# Altium schematic sheet testcore.SchDoc(testcore)
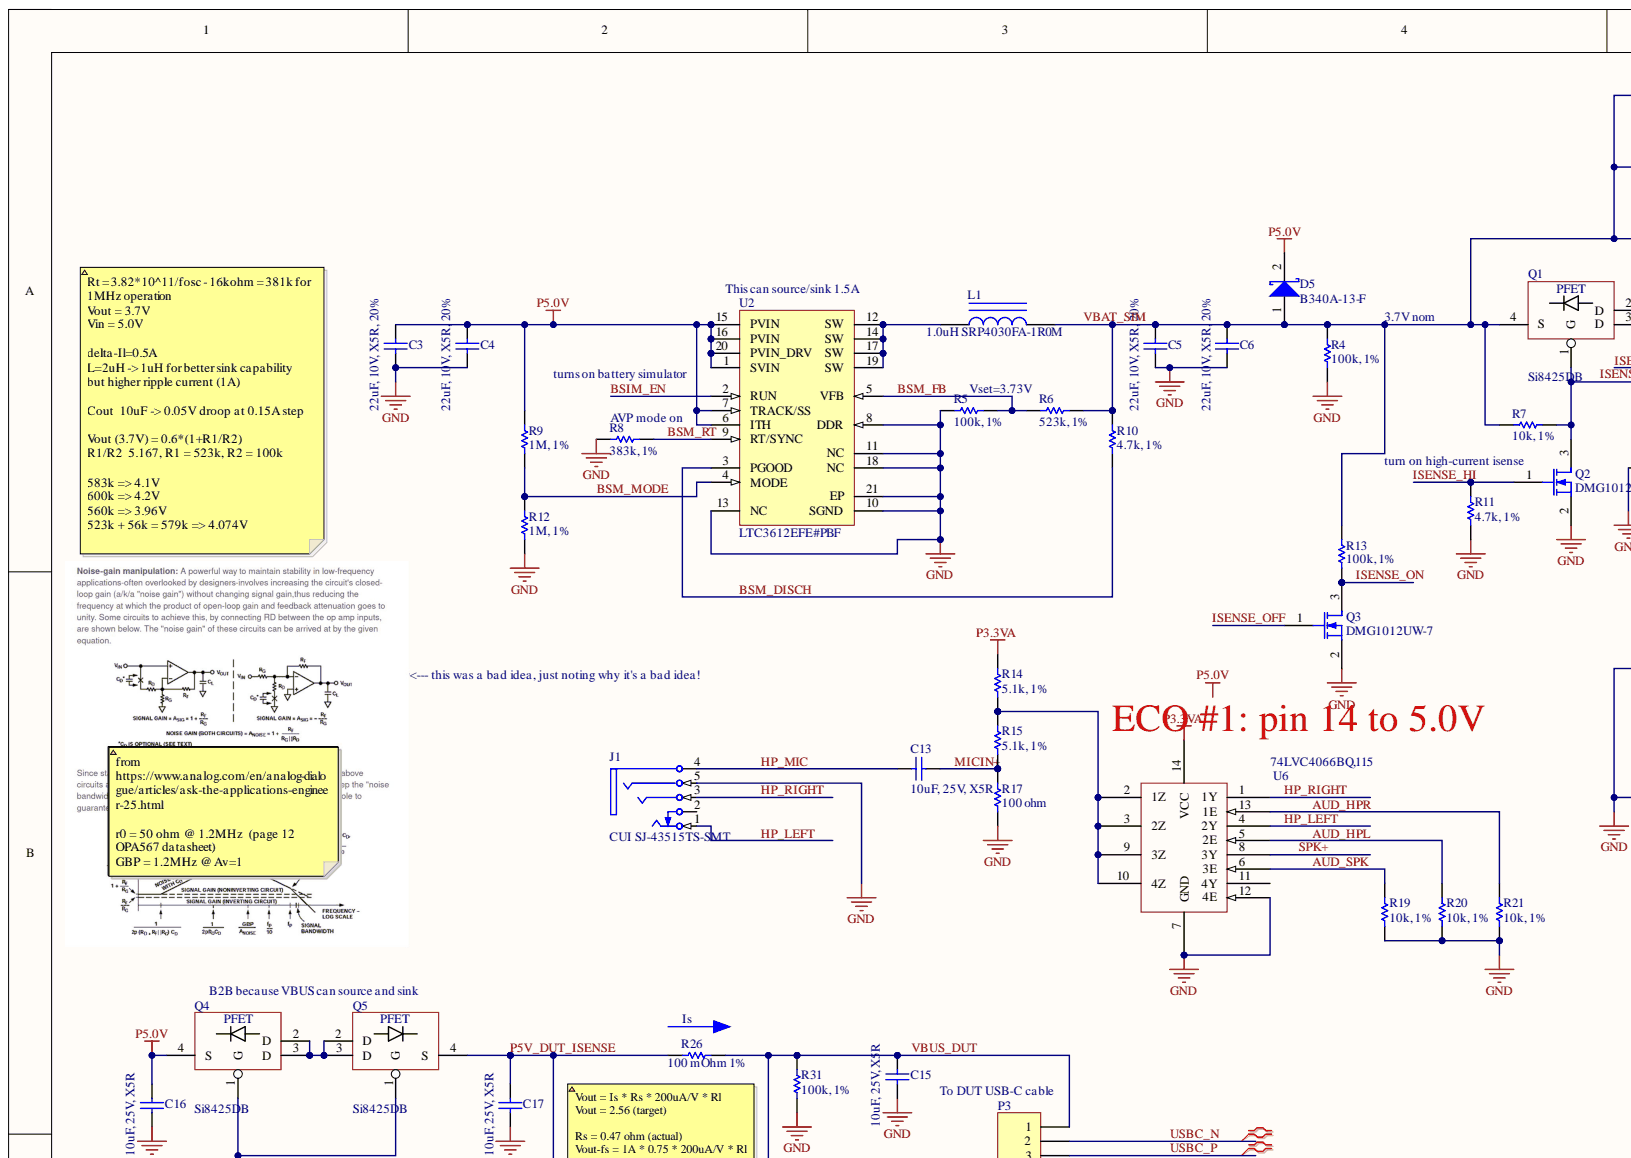
[diagram p0: testcore.SchDoc, top left]
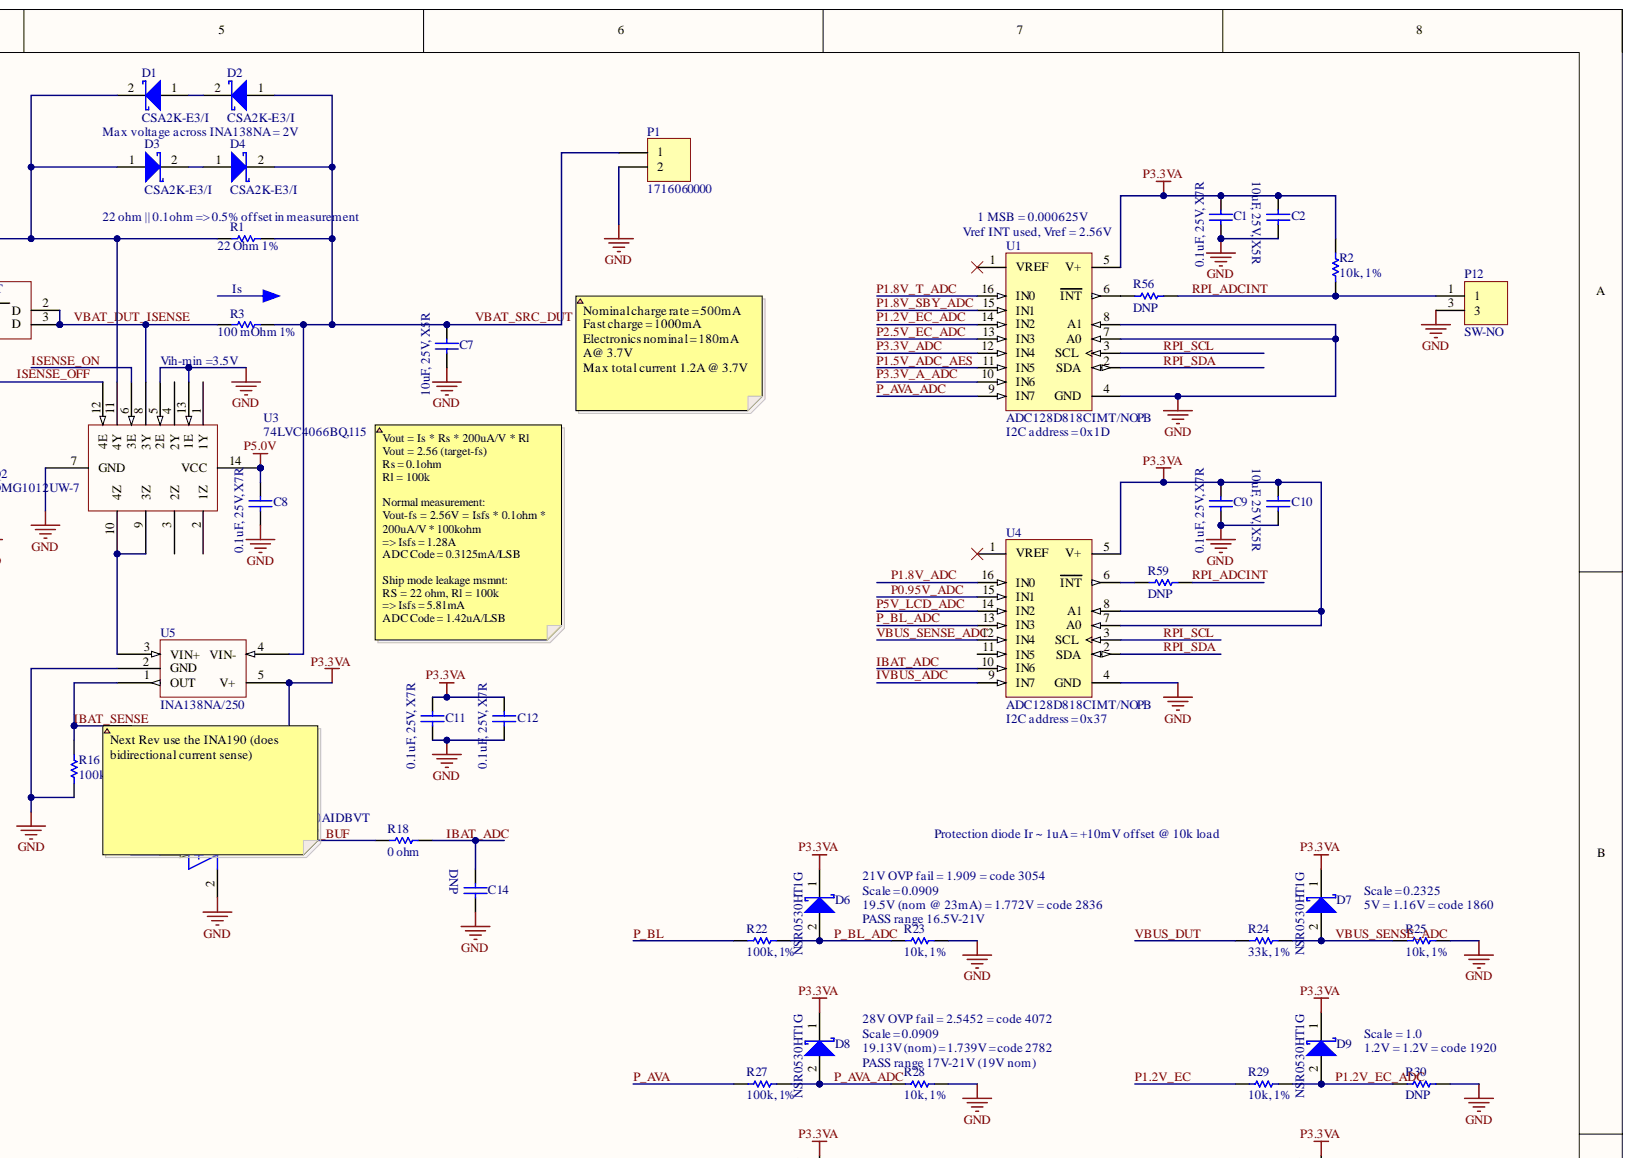
[diagram p1: testcore.SchDoc, top right]
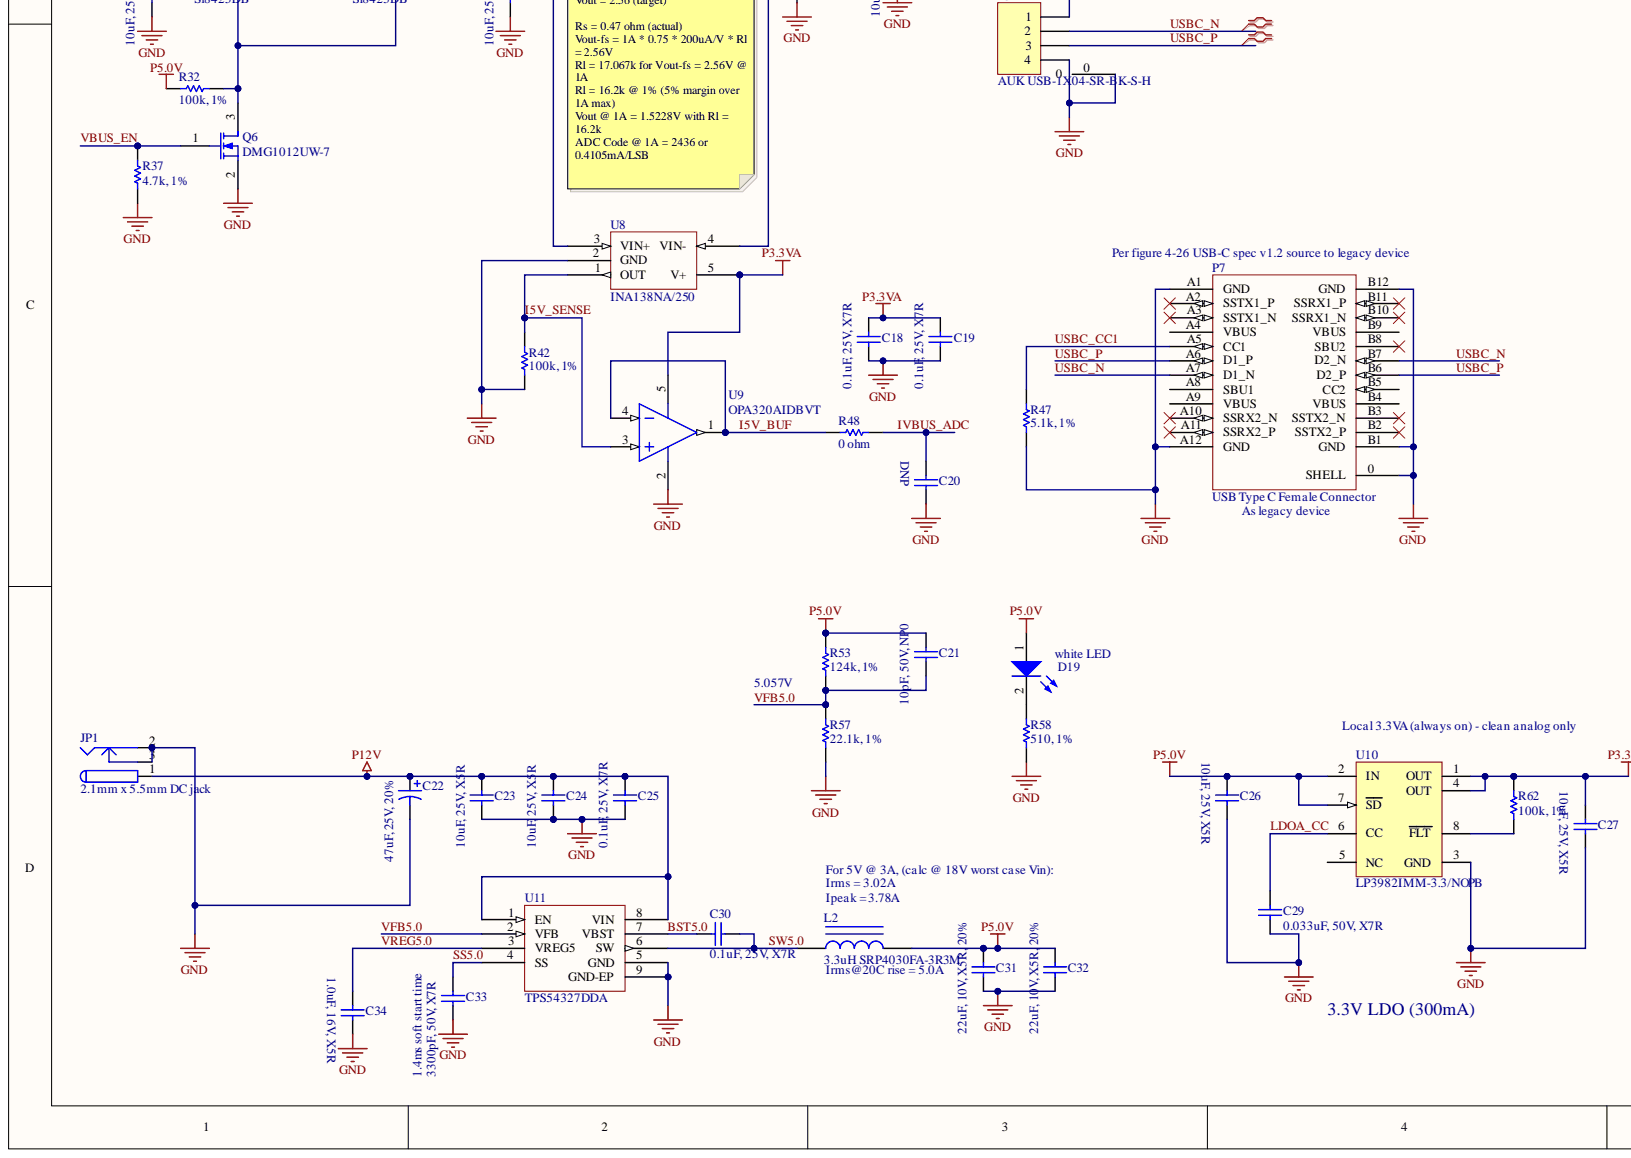
[diagram p2: testcore.SchDoc, bottom left]
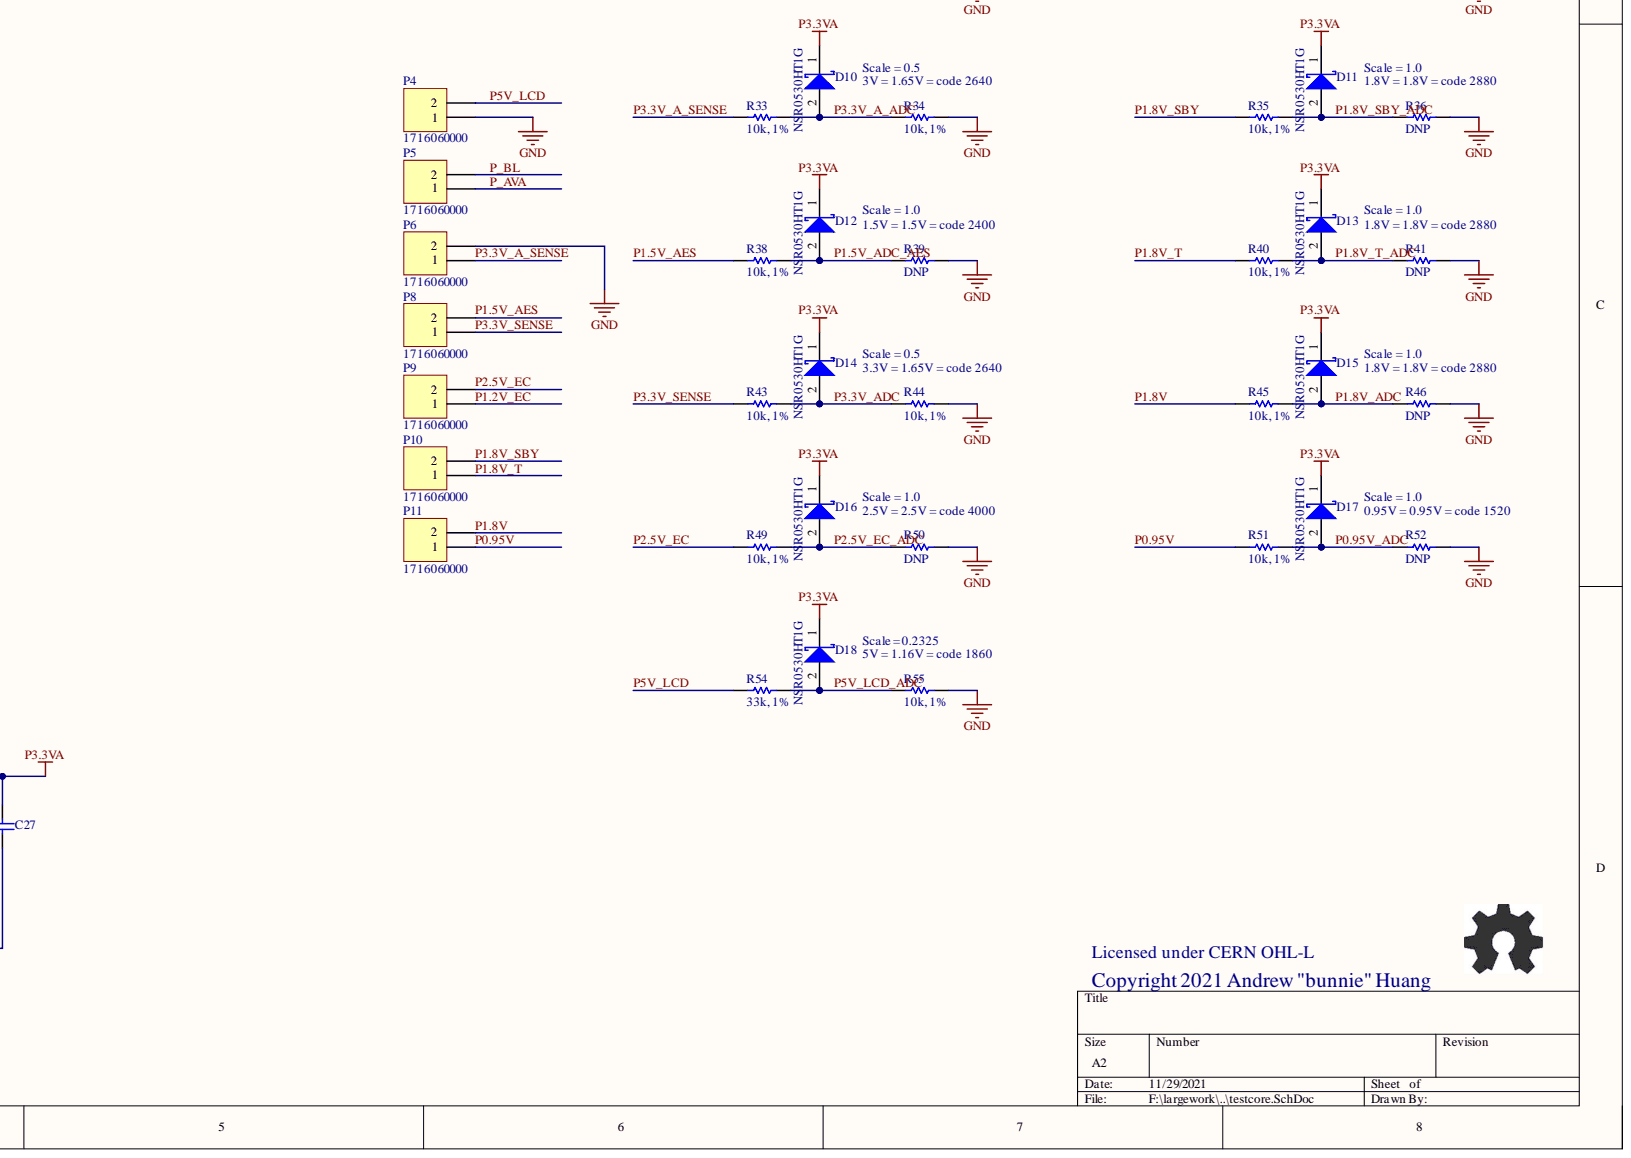
[diagram p3: testcore.SchDoc, bottom right]

## Parts
C1  0.1uF, 25V, X7R  [B_CAP0603]
C2  10uF, 25V, X5R  [B_CAP0805]
C3  22uF, 10V, X5R, 20%  [B_CAP0805]
C4  22uF, 10V, X5R, 20%  [B_CAP0805]
C5  22uF, 10V, X5R, 20%  [B_CAP0805]
C6  22uF, 10V, X5R, 20%  [B_CAP0805]
C7  10uF, 25V, X5R  [B_CAP0805]
C8  0.1uF, 25V, X7R  [B_CAP0603]
C9  0.1uF, 25V, X7R  [B_CAP0603]
C10  10uF, 25V, X5R  [B_CAP0805]
C11  0.1uF, 25V, X7R  [B_CAP0603]
C12  0.1uF, 25V, X7R  [B_CAP0603]
C13  10uF, 25V, X5R  [B_CAP0805]
C14  DNP  [B_CAP0603]
C15  10uF, 25V, X5R  [B_CAP0805]
C16  10uF, 25V, X5R  [B_CAP0805]
C17  10uF, 25V, X5R  [B_CAP0805]
C18  0.1uF, 25V, X7R  [B_CAP0603]
C19  0.1uF, 25V, X7R  [B_CAP0603]
C20  DNP  [B_CAP0603]
C21  10pF, 50V, NP0  [B_CAP0603]
C22  47uF, 25V, 20%  [CAPAE7066X61N]
C23  10uF, 25V, X5R  [B_CAP0805]
C24  10uF, 25V, X5R  [B_CAP0805]
C25  0.1uF, 25V, X7R  [B_CAP0603]
C26  10uF, 25V, X5R  [B_CAP0805]
C27  10uF, 25V, X5R  [B_CAP0805]
C29  0.033uF, 50V, X7R  [B_CAP0603]
C30  0.1uF, 25V, X7R  [B_CAP0603]
C31  22uF, 10V, X5R, 20%  [B_CAP0805]
C32  22uF, 10V, X5R, 20%  [B_CAP0805]
C33  3300pF, 50V, X7R  [B_CAP0603]
C34  1.0uF, 16V, X5R  [B_CAP0603]
D1  CSA2K-E3/I  [DSO-C2/X1.8]
D2  CSA2K-E3/I  [DSO-C2/X1.8]
D3  CSA2K-E3/I  [DSO-C2/X1.8]
D4  CSA2K-E3/I  [DSO-C2/X1.8]
D5  B340A-13-F  [DSO-C2/X1.8]
D6  NSR0530HT1G  [SOD-323]
D7  NSR0530HT1G  [SOD-323]
D8  NSR0530HT1G  [SOD-323]
D9  NSR0530HT1G  [SOD-323]
D10  NSR0530HT1G  [SOD-323]
D11  NSR0530HT1G  [SOD-323]
D12  NSR0530HT1G  [SOD-323]
D13  NSR0530HT1G  [SOD-323]
D14  NSR0530HT1G  [SOD-323]
D15  NSR0530HT1G  [SOD-323]
D16  NSR0530HT1G  [SOD-323]
D17  NSR0530HT1G  [SOD-323]
D18  NSR0530HT1G  [SOD-323]
D19  white LED  [B_LED0805]
J1  CUI SJ-43515TS-SMT  MPN: SJ-43515TS-SMT  VENDOR: CUI  [CUI_SJ_43515TS-SMT]
JP1  2.1mm x 5.5mm DC jack  [CUI_PJ_002AH_SMT]
L1  1.0uH SRP4030FA-1R0M  MPN: SRP4030FA-1R0M  [COILCRAFT_XEL4030]
L2  3.3uH SRP4030FA-3R3M  [COILCRAFT_XEL4030]
P1  1716060000  [weidmuller_1716060000]
P3  AUK USB-1X04-SR-BK-S-H  [USB_TYPEA_TH_SINGLE]
P4  1716060000  [weidmuller_1716060000]
P5  1716060000  [weidmuller_1716060000]
P6  1716060000  [weidmuller_1716060000]
P7  USB Type C Female Connector  [HIROSE_CX90B1-24P]
P8  1716060000  [weidmuller_1716060000]
P9  1716060000  [weidmuller_1716060000]
P10  1716060000  [weidmuller_1716060000]
P11  1716060000  [weidmuller_1716060000]
P12  SW-NO  [CK_KS11R22CQD]
Q1  Si8425DB  [VISHAY_MICRO_FOOT_4_BUMP]
Q2  DMG1012UW-7  [SOT323-3AN]
Q3  DMG1012UW-7  [SOT323-3AN]
Q4  Si8425DB  [VISHAY_MICRO_FOOT_4_BUMP]
Q5  Si8425DB  [VISHAY_MICRO_FOOT_4_BUMP]
Q6  DMG1012UW-7  [SOT323-3AN]
R1  22 Ohm 1%  [B_RES1206]
R2  10k, 1%  [B_RES0603]
R3  100 mOhm 1%  [B_RES1206]
R4  100k, 1%  [B_RES0603]
R5  100k, 1%  [B_RES0603]
R6  523k, 1%  [B_RES0603]
R7  10k, 1%  [B_RES0603]
R8  383k, 1%  [B_RES0603]
R9  1M, 1%  [B_RES0603]
R10  4.7k, 1%  [B_RES0603]
R11  4.7k, 1%  [B_RES0603]
R12  1M, 1%  [B_RES0603]
R13  100k, 1%  [B_RES0603]
R14  5.1k, 1%  [B_RES0603]
R15  5.1k, 1%  [B_RES0603]
R16  100k, 1%  [B_RES0603]
R17  100 ohm  [B_RES0603]
R18  0 ohm  [B_RES0603]
R19  10k, 1%  [B_RES0603]
R20  10k, 1%  [B_RES0603]
R21  10k, 1%  [B_RES0603]
R22  100k, 1%  [B_RES0603]
R23  10k, 1%  [B_RES0603]
R24  33k, 1%  [B_RES0603]
R25  10k, 1%  [B_RES0603]
R26  100 mOhm 1%  [B_RES1206]
R27  100k, 1%  [B_RES0603]
R28  10k, 1%  [B_RES0603]
R29  10k, 1%  [B_RES0603]
R30  DNP  [B_RES0603]
R31  100k, 1%  [B_RES0603]
R32  100k, 1%  [B_RES0603]
R33  10k, 1%  [B_RES0603]
R34  10k, 1%  [B_RES0603]
R35  10k, 1%  [B_RES0603]
R36  DNP  [B_RES0603]
R37  4.7k, 1%  [B_RES0603]
R38  10k, 1%  [B_RES0603]
R39  DNP  [B_RES0603]
R40  10k, 1%  [B_RES0603]
R41  DNP  [B_RES0603]
R42  100k, 1%  [B_RES0603]
R43  10k, 1%  [B_RES0603]
R44  10k, 1%  [B_RES0603]
R45  10k, 1%  [B_RES0603]
R46  DNP  [B_RES0603]
R47  5.1k, 1%  [B_RES0603]
R48  0 ohm  [B_RES0603]
R49  10k, 1%  [B_RES0603]
R50  DNP  [B_RES0603]
R51  10k, 1%  [B_RES0603]
R52  DNP  [B_RES0603]
R53  124k, 1%  [B_RES0603]
R54  33k, 1%  [B_RES0603]
R55  10k, 1%  [B_RES0603]
R56  DNP  [B_RES0603]
R57  22.1k, 1%  [B_RES0603]
R58  510, 1%  [B_RES0603]
R59  DNP  [B_RES0603]
R62  100k, 1%  [B_RES0603]
U1  ADC128D818CIMT/NOPB  [MTC16_N]
U2  LTC3612EFE#PBF  [LT-FE-20-EPV-CB_L]
U3  74LVC4066BQ,115  [NXP_DHVQFN14]
U4  ADC128D818CIMT/NOPB  [MTC16_N]
U5  INA138NA/250  [SOT23-5AN]
U6  74LVC4066BQ,115  [NXP_DHVQFN14]
U7  OPA320AIDBVT  [TI-DBV5_N]
U8  INA138NA/250  [SOT23-5AN]
U9  OPA320AIDBVT  [TI-DBV5_N]
U10  LP3982IMM-3.3/NOPB  [MUA08A_N]
U11  TPS54327DDA  [SOIC127P600-8DN]

## Nets
GND: C1.2 C2.2 C3.2 C4.2 C5.2 C6.2 C7.1 C8.2 C9.2 C10.2 C11.2 C12.2 C14.2 C15.1 C16.1 C17.1 C18.2 C19.2 C20.2 C22.2 C23.1 C24.1 C25.2 C26.2 C27.2 C29.1 C31.2 C32.2 C33.2 C34.2 J1.5 JP1.2 JP1.3 P1.2 P3.0 P3.4 P4.1 P6.2 P7.0(SHELL) P7.A1(GND) P7.A12(GND) P7.B1(GND) P7.B12(GND) P12.3 Q2.2 Q3.2 Q6.2 R4.2 R5.1 R8.2 R11.1 R12.1 R16.2 R17.1 R19.1 R20.1 R21.1 R23.2 R25.2 R28.2 R30.2 R31.2 R34.2 R36.2 R37.1 R39.2 R41.2 R42.2 R44.2 R46.2 R47.1 R50.2 R52.2 R55.2 R57.1 R58.2 U1.4(GND) U1.7(A0) U1.8(A1) U2.8(DDR) U2.10(SGND) U2.11(NC) U2.13(NC) U2.18(NC) U2.21(EP) U3.5(2E) U3.7(GND) U3.13(1E) U4.4(GND) U5.2(GND) U6.7(GND) U6.12(4E) U7.2 U8.2(GND) U9.2 U10.3(GND) U11.5(GND) U11.9(GND-EP)
P3.3VA: C1.1 C2.1 C9.1 C10.1 C11.1 C12.1 C18.1 C19.1 C27.1 D6.1 D7.1 D8.1 D9.1 D10.1 D11.1 D12.1 D13.1 D14.1 D15.1 D16.1 D17.1 D18.1 R2.2 R14.2 R62.2 U1.5(V+) U4.5(V+) U4.7(A0) U4.8(A1) U5.5(V+) U6.14(VCC) U7.5 U8.5(V+) U9.5 U10.1(OUT) U10.4(OUT)
P12V: C22.1 C23.2 C24.2 C25.1 JP1.1 U11.1(EN) U11.8(VIN)
AUD_HPL: R20.2 U6.5(2E)
AUD_HPR: R21.2 U6.13(1E)
AUD_SPK: R19.2 U6.6(3E)
BSIM_EN: U2.2(RUN)
BSM_DISCH: R10.1 U2.3(PGOOD)
BSM_FB: R5.2 R6.1 U2.5(VFB)
BSM_MODE: R9.1 R12.2 U2.4(MODE)
BSM_RT: R8.1 U2.9(RT/SYNC)
BST5.0: C30.2 U11.7(VBST)
HP_LEFT: J1.1 U6.4(2Y)
HP_MIC: C13.2 J1.4
HP_RIGHT: J1.3 U6.1(1Y)
I5V_BUF: R48.1 U9.1 U9.4
I5V_SENSE: R42.1 U8.1(OUT) U9.3
IBAT_ADC: C14.1 R18.2 U4.10(IN6)
IBAT_BUF: R18.1 U7.1 U7.4
IBAT_SENSE: R16.1 U5.1(OUT) U7.3
ISENSE_HI: Q2.1 R11.2
ISENSE_OFF: Q1.1(G) Q2.3 Q3.1 R7.2 U3.12(4E)
ISENSE_ON: Q3.3 R13.2 U3.6(3E)
IVBUS_ADC: C20.1 R48.2 U4.9(IN7)
LDOA_CC: C29.2 U10.6(CC)
MICIN+: C13.1 R15.1 R17.2
P0.95V: P11.1 R51.1
P0.95V_ADC: D17.2 R51.2 R52.1 U4.15(IN1)
P1.2V_EC: P9.1 R29.1
P1.2V_EC_ADC: D9.2 R29.2 R30.1 U1.14(IN2)
P1.5V_ADC_AES: D12.2 R38.2 R39.1 U1.11(IN5)
P1.5V_AES: P8.2 R38.1
P1.8V: P11.2 R45.1
P1.8V_ADC: D15.2 R45.2 R46.1 U4.16(IN0)
P1.8V_SBY: P10.2 R35.1
P1.8V_SBY_ADC: D11.2 R35.2 R36.1 U1.15(IN1)
P1.8V_T: P10.1 R40.1
P1.8V_T_ADC: D13.2 R40.2 R41.1 U1.16(IN0)
P2.5V_EC: P9.2 R49.1
P2.5V_EC_ADC: D16.2 R49.2 R50.1 U1.13(IN3)
P3.3V_A_ADC: D10.2 R33.2 R34.1 U1.10(IN6)
P3.3V_A_SENSE: P6.1 R33.1
P3.3V_ADC: D14.2 R43.2 R44.1 U1.12(IN4)
P3.3V_SENSE: P8.1 R43.1
P5.0V: C3.1 C4.1 C8.1 C16.2 C17.2 C21.1 C26.1 C31.1 C32.1 D5.2 D18.2 D19.1 L2.2 P4.2 Q4.4(S) Q5.4(S) R9.2 R26.1 R32.2 R53.2 R54.1 R54.2 R55.1 U2.1(SVIN) U2.6(ITH) U2.7(TRACK/SS) U2.15(PVIN) U2.16(PVIN) U2.20(PVIN_DRV) U3.14(VCC) U4.14(IN2) U8.3(VIN+) U10.2(IN) U10.7(SD)  [also labelled P5V_DUT_ISENSE, P5V_LCD, P5V_LCD_ADC]
P_AVA: P5.1 R27.1
P_AVA_ADC: D8.2 R27.2 R28.1 U1.9(IN7)
P_BL: P5.2 R22.1
P_BL_ADC: D6.2 R22.2 R23.1 U4.13(IN3)
RPI_ADCINT: P12.1 R2.1 R56.2 R59.2
RPI_SCL: U1.3(SCL) U4.3(SCL)
RPI_SDA: U1.2(SDA) U4.2(SDA)
SPK+: U6.8(3Y)
SS5.0: C33.1 U11.4(SS)
SW5.0: C30.1 L2.1 U11.6(SW)
USBC_CC1: P7.A5(CC1) R47.2
USBC_N: P3.2 P7.A7(D1_N) P7.B7(D2_N)
USBC_P: P3.3 P7.A6(D1_P) P7.B6(D2_P)
VBAT_DUT_ISENSE: Q1.2(D) Q1.3(D) R3.1 U3.8(3Y)
VBAT_SIM: C5.1 C6.1 D1.2 D3.1 D5.1 L1.2 Q1.4(S) R1.1 R4.1 R6.2 R7.1 R10.2 R13.1 U3.11(4Y)
VBAT_SRC_DUT: C7.2 D2.1 D4.2 P1.1 R1.2 R3.2 U5.4(VIN-)
VBUS_DUT: C15.2 P3.1 R24.1 R26.2 R31.1 U8.4(VIN-)
VBUS_EN: Q6.1 R37.2
VBUS_SENSE_ADC: D7.2 R24.2 R25.1 U4.12(IN4)
VFB5.0: C21.2 R53.1 R57.2 U11.2(VFB)
VREG5.0: C34.1 U11.3(VREG5)
NetD1_1: D1.1 D2.2
NetD3_2: D3.2 D4.1
NetD19_2: D19.2 R58.1
NetL1_1: L1.1 U2.12(SW) U2.14(SW) U2.17(SW) U2.19(SW)
NetQ4_1: Q4.1(G) Q5.1(G) Q6.3 R32.1
NetQ4_2: Q4.2(2.4_ohm_D) Q4.3(D) Q5.2(D) Q5.3(D)
NetR14_1: R14.1 R15.2 U6.2(1Z) U6.3(2Z) U6.9(3Z) U6.10(4Z)
NetR56_1: R56.1 U1.6(INT)
NetR59_1: R59.1 U4.6(INT)
NetR62_1: R62.1 U10.8(FLT)
NetU3_9: U3.9(3Z) U3.10(4Z) U5.3(VIN+)

Not connected: J1 2; P7 A2(SSTX1_P) A3(SSTX1_N) A4(VBUS) A8(SBU1) A9(VBUS) A10(SSRX2_N) A11(SSRX2_P) B2(SSTX2_P) B3(SSTX2_N) B4(VBUS) B5(CC2) B8(SBU2) B9(VBUS) B10(SSRX1_N) B11(SSRX1_P); U1 1(VREF); U3 1(1Y) 2(1Z) 3(2Z) 4(2Y); U4 1(VREF) 11(IN5); U6 11(4Y); U10 5(NC)

## Library data of the parts
C1 C8 C9 C11 C12 C18 C19 C25 C30 = Cap Semi: Capacitor (Semiconductor SIM Model); MPN: CC0603KRX7R8BB104; MYPN: C-104-25-X7R-0603; VENDOR: Murata; Component Kind: Standard; Library Name: Miscellaneous Devices.IntLib; Signal Integrity: Cap Semi; Simulation: CAP
C2 C7 C10 C13 C15-C17 C23 C24 C26 C27 = Cap Semi: Capacitor (Semiconductor SIM Model); MPN: GRM21BR61E106KA73L; MYPN: C-106-25-X5R-0805; VENDOR: Murata; Component Kind: Standard; Library Name: Miscellaneous Devices.IntLib; Signal Integrity: Cap Semi; Simulation: CAP
C3-C6 C31 C32 = Cap Semi: Capacitor (Semiconductor SIM Model); MPN: GRM21BR61A226ME51L; MFG: Murata; MYPN: C-226-10-X5R-0805; Component Kind: Standard; LatestRevisionDate: 17-Jul-2002; LatestRevisionNote: Re-released for DXP Platform.; Library Name: Miscellaneous Devices.IntLib; PackageReference: C1206; Published: 8-Jun-2000; Signal Integrity: Cap Semi; Simulation: CAP; Publisher: Altium Limited
C14 C20 = Cap Semi: Capacitor (Semiconductor SIM Model); VENDOR: None; Component Kind: Standard; Library Name: Miscellaneous Devices.IntLib; MPN: DNP; MYPN: DNP; Signal Integrity: Cap Semi; Simulation: CAP
C21 = Cap Semi: Capacitor (Semiconductor SIM Model); MPN: CL10C100JB8NFNC; MYPN: C-100-50-NP0-0603; VENDOR: Samsung; Component Kind: Standard; Library Name: Miscellaneous Devices.IntLib; Signal Integrity: Cap Semi; Simulation: CAP
C22 = Cap Pol1: Polarized Capacitor (Radial); MPN: UCL1E470MCL1GS; MYPN: C-476-25-UCL-6.3x5.8; VENDOR: Nichicon; Component Kind: Standard; Library Name: Miscellaneous Devices.IntLib; Signal Integrity: Cap Pol; Simulation: CAP
C29 = Cap Semi: Capacitor (Semiconductor SIM Model); MPN: CL10B333KB8NNNC; MYPN: C-333-50-X7R-0603; VENDOR: Samsung; Component Kind: Standard; Library Name: Miscellaneous Devices.IntLib; Signal Integrity: Cap Semi; Simulation: CAP
C33 = Cap Semi: Capacitor (Semiconductor SIM Model); MPN: CL10B332KB8NNNC; MYPN: C-332-50-X7R-0603; VENDOR: Samsung; Component Kind: Standard; Library Name: Miscellaneous Devices.IntLib; Signal Integrity: Cap Semi; Simulation: CAP
C34 = Cap Semi: Capacitor (Semiconductor SIM Model); MPN: CL10B105MO8NNWC; MYPN: C-105-16-X5R-0603; VENDOR: Samsung; Component Kind: Standard; Library Name: Miscellaneous Devices.IntLib; Signal Integrity: Cap Semi; Simulation: CAP
D1-D4 = D Schottky: Schottky Diode; MYPN: D-S-2-800-0p9-SMA; VENDOR: Vishay; Component Kind: Standard; Library Name: Miscellaneous Devices.IntLib; MPN: CSA2K-E3/I; Revision: July-2002: Re-released for DXP Platform.; Signal Integrity: D Schottky; Simulation: SKYDIODE
D5 = D Schottky: Schottky Diode; MYPN: D-S-3-40-0p5-SMA; VENDOR: Diodes Inc; Component Kind: Standard; Library Name: Miscellaneous Devices.IntLib; MPN: B340A-13-F; Revision: July-2002: Re-released for DXP Platform.; Signal Integrity: D Schottky; Simulation: SKYDIODE
D6-D18 = D Schottky: Schottky Diode; MPN: NSR0530H-D; MYPN: D-S-0p5-30-0p37-SOD323; VENDOR: Semtech HK LTD; Component Kind: Standard; Library Name: Miscellaneous Devices.IntLib; Revision: July-2002: Re-released for DXP Platform.; Signal Integrity: D Schottky; Simulation: SKYDIODE
D19 = LED2: Typical RED, GREEN, YELLOW, AMBER GaAs LED; MPN: IN-S85AT5UW; MYPN: D-LED-WHITE1-0805; VENDOR: Inolux; Component Kind: Standard; Library Name: Miscellaneous Devices.IntLib; Simulation: LED2
J1 = Phonejack4 TN: Jack Socket, 3.5mm, SMT, 4-conductor; DrillAndSlotSize: Corresponds to nominal value.; PackageDocument: 2003; PCB_Layout: Complies with manufacturer's recommendation.; Component Kind: Standard; ComponentLink1Description: Manufacturer Link; ComponentLink1URL: http://www.cliffuk.co.uk/; DatasheetDocument: 2003; Library Name: commonsch.lib; MYPN: SJ-43515TS-SMT; PackageReference: S1VS_SSB; Published: 15-Jul-2004; Signal Integrity: JACK Socket; Publisher: Altium Limited
JP1 = PWR2.5: Low Voltage Power Supply Connector; MPN: DC-480-2.0A-SMT; MYPN: J-PJ-002AH-SMT-TR; VENDOR: KAWEEI; Component Kind: Standard; Datasheet: Latest Revision: 09-May-2002; Library Name: Miscellaneous Connectors.IntLib; Published: 17-Dec-2003; Signal Integrity: Connector; Publisher: Altium Limited
L1 = Inductor Iron: Magnetic-Core Inductor; MYPN: L-XEL4030-332ME_; VENDOR: Bourns, Inc; Component Kind: Standard; Library Name: Miscellaneous Devices.IntLib; Signal Integrity: Inductor Iron; Simulation: INDUCTOR
L2 = Inductor Iron: Magnetic-Core Inductor; MPN: XEL4030-332ME_; MYPN: L-XEL4030-332ME_; VENDOR: COILCRAFT; Component Kind: Standard; Library Name: Miscellaneous Devices.IntLib; Signal Integrity: Inductor Iron; Simulation: INDUCTOR
P1 P4-P6 P8-P11 = Header 2: Header, 2-Pin; MYPN: J-TB-2P-5.08; VENDOR: Weidmuller; Component Kind: Standard; LatestRevisionDate: 17-Jul-2002; LatestRevisionNote: Re-released for DXP Platform.; Library Name: Miscellaneous Connectors.IntLib; MPN: 1716060000; Signal Integrity: Connector; Publisher: Altium Limited
P3 = Header 4: Header, 4-Pin; Component Kind: Standard; LatestRevisionDate: 17-Jul-2002; LatestRevisionNote: Re-released for DXP Platform.; Library Name: Miscellaneous Connectors.IntLib; Signal Integrity: Connector; Publisher: Altium Limited
P7 = USB_TYPE_C: USB Type C Connector; MPN: 1-2295018-2; MYPN: P-1-2295018-2; VENDOR: TE Connectivity AMP Connectors; Component Kind: Standard; Library Name: commonsch-new.SchLib
P12 = Header 2: Header, 2-Pin; Component Kind: Standard; LatestRevisionDate: 17-Jul-2002; LatestRevisionNote: Re-released for DXP Platform.; Library Name: Miscellaneous Connectors.IntLib; Signal Integrity: Connector; Publisher: Altium Limited
Q1 Q4 Q5 = Si8425DB: P-channel 20V 6.2A 27mOhm @ -2.5V; MPN: Si8425DB-T1-E1; MYPN: QSi8525; VENDOR: Vishay Siliconix; Component Kind: Standard; Library Name: temp-to-merge.SchLib
Q2 Q3 Q6 = MOSFET-N: N-Channel MOSFET; MYPN: Q-DMG1012UW-7; VENDOR: Diodes Inc; Component Kind: Standard; LatestRevisionDate: 17-Jul-2002; LatestRevisionNote: Re-released for DXP Platform.; Library Name: Miscellaneous Devices.IntLib; MPN: DMG1012UW-7; PackageReference: E3; PackageVersion: 1995/1996; Published: 8-Jun-2000; Simulation: NMOS; Publisher: Altium Limited
R1 = Res1: Resistor; MPN: ERJ-8ENF22R0V; MYPN: R-220-1P-1_4W-1206; VENDOR: Panasonic; Component Kind: Standard; Library Name: Miscellaneous Devices.IntLib; Signal Integrity: Res; Simulation: RESISTOR
R2 R7 R19-R21 R23 R25 R28 R29 R33-R35 R38 R40 R43-R45 R49 R51 R55 = Res1: Resistor; MPN: RC0603FR-0710KL; MYPN: R-103-1P-1_16W-0603; VENDOR: Yageo; Component Kind: Standard; Library Name: Miscellaneous Devices.IntLib; Signal Integrity: Res; Simulation: RESISTOR
R3 R26 = Res1: Resistor; MPN: CSR1206FTR100; MYPN: R-0R100-1P-1_2W-1206; VENDOR: Stackpole; Component Kind: Standard; Library Name: Miscellaneous Devices.IntLib; Signal Integrity: Res; Simulation: RESISTOR
R4 R5 R13 R16 R22 R27 R31 R32 R42 R62 = Res1: Resistor; MPN: RC0603FR-07100KL; MYPN: R-104-1P-1_16W-0603; VENDOR: Yageo; Component Kind: Standard; Library Name: Miscellaneous Devices.IntLib; Signal Integrity: Res; Simulation: RESISTOR
R6 = Res1: Resistor; MPN: RC0603FR-07523KL; MYPN: R-5233-1P-1_16W-0603; VENDOR: Yageo; Component Kind: Standard; Library Name: Miscellaneous Devices.IntLib; Signal Integrity: Res; Simulation: RESISTOR
R8 = Res1: Resistor; MPN: RC0603FR-07383KL; MYPN: R-3833-1P-1_16W-0402; VENDOR: Yageo; Component Kind: Standard; Library Name: Miscellaneous Devices.IntLib; Signal Integrity: Res; Simulation: RESISTOR
R9 R12 = Res1: Resistor; MPN: RC0603FR-071ML; MYPN: R-105-1P-1_16W-0603; VENDOR: Yageo; Component Kind: Standard; Library Name: Miscellaneous Devices.IntLib; Signal Integrity: Res; Simulation: RESISTOR
R10 R11 R37 = Res1: Resistor; MPN: RC0603FR-074K7L; MYPN: R-472-1P-1_16W-0603; VENDOR: Yageo; Component Kind: Standard; Library Name: Miscellaneous Devices.IntLib; Signal Integrity: Res; Simulation: RESISTOR
R14 R15 R47 = Res1: Resistor; MPN: RC0603FR-075K1L; MYPN: R-512-1P-1_16W-0603; VENDOR: Yageo; Component Kind: Standard; Library Name: Miscellaneous Devices.IntLib; Signal Integrity: Res; Simulation: RESISTOR
R17 = Res1: Resistor; MPN: RC0603FR-07100RL; MYPN: R-101-1P-1_16W-0603; VENDOR: Yageo; Component Kind: Standard; Library Name: Miscellaneous Devices.IntLib; Signal Integrity: Res; Simulation: RESISTOR
R18 R48 = Res1: Resistor; MPN: RC0603JR-070RL; MYPN: R-0R0-1P-1_16W-0603; VENDOR: Yageo; Component Kind: Standard; Library Name: Miscellaneous Devices.IntLib; Signal Integrity: Res; Simulation: RESISTOR
R24 R54 = Res1: Resistor; MPN: RC0603FR-0733KL; MYPN: R-333-1P-1_16W-0603; VENDOR: Yageo; Component Kind: Standard; Library Name: Miscellaneous Devices.IntLib; Signal Integrity: Res; Simulation: RESISTOR
R30 R36 R39 R41 R46 R50 R52 R56 R59 = Res1: Resistor; MPN: ANY; Component Kind: Standard; Library Name: Miscellaneous Devices.IntLib; MYPN: DNP; Signal Integrity: Res; Simulation: RESISTOR; VENDOR: ANY
R53 = Res1: Resistor; MPN: RC0603FR-07124KL; MYPN: R-1243-1P-1_16W-0603; VENDOR: Yageo; Component Kind: Standard; Library Name: Miscellaneous Devices.IntLib; Signal Integrity: Res; Simulation: RESISTOR
R57 = Res1: Resistor; MPN: RC0603FR-0722K1L; MYPN: R-2212-1P-1_16W-0603; VENDOR: Yageo; Component Kind: Standard; Library Name: Miscellaneous Devices.IntLib; Signal Integrity: Res; Simulation: RESISTOR
R58 = Res1: Resistor; MPN: RC0603FR-07510RL; MYPN: R-511-1P-1_16W-0603; Value: 100k; VENDOR: Yageo; Component Kind: Standard; LatestRevisionDate: 17-Jul-2002; LatestRevisionNote: Re-released for DXP Platform.; Library Name: Miscellaneous Devices.IntLib; PackageReference: AXIAL-0.3; Published: 8-Jun-2000; Signal Integrity: Res; Simulation: RESISTOR; Publisher: Altium Limited
U1 U4 = ADC128D818CIMT/NOPB: 12-Bit, 8-Channel, ADC System Monitor with Temperature Sensor, Internal/External Reference, and I2C Interface, 16 pin TSSOP, Pb-Free; Manufacturer: National Semiconductor; CompType: ADC IC; High-Reliability: Y; MYPN: U-ADC128D818CIMT/NOPB; PackageTopMarking: NSUZXYTT*128D818*CIMT; PowerWise: N; VENDOR: TI; Code_JEDEC: MO-153-AB; Component Kind: Standard; ComponentLink1Description: ADC128D818 Datasheet; ComponentLink1URL: http://www.national.com/ds/AD/ADC128D818.pdf; ComponentLink2Description: ADC128D818 Web Page; ComponentLink2URL: http://www.national.com/pf/AD/ADC128D818.html; ComponentLink3Description: MTC16 Package Page; ComponentLink3URL: http://www.national.com/packaging/folders/mtc16.html; DatasheetVersion: Feb-2010; Library Name: National Semiconductor ADC.IntLib; MPN: ADC128D818CIMT/NOPB; PackageDescription: Molded TSSOP, Jedec, 5 x 4.4 x 0.9mm Body, 16 Lead, 0.65mm Pitch; PackageReference: MTC16; PackageVersion: revD, Jun-2003; PartNumber: ADC128D818CIMT/NOPB; PinCount: 16; RoHS: Y
U2 = CMP-0492-00542-1: 3 A, 4 MHz Monolithic Synchronous Step-Down DC/DC Converter, 20-pin SOP (FE-20-EPV-CB), -40 to 125 degC, Pb-Free; Frequency Adjust Range: Up - 4MHz; Number of Outputs: 1; VENDOR: Linear Technology; Component Kind: Standard; ComponentLink1Description: Manufacturer URL; ComponentLink1URL: http://www.linear.com/; ComponentLink2Description: Datasheet; ComponentLink2URL: http://cds.linear.com/docs/en/datasheet/3612fa.pdf; ComponentLink3Description: Package Specification; ComponentLink3URL: http://cds.linear.com/docs/en/packaging/05081663__J_FE20(CB).pdf; DatasheetVersion: Rev. A; Library Name: Altium Content Vault; Mounting Technology: Surface Mount; MPN: LTC3612EFE#PBF; PackageDescription: 20-Pin Plastic TSSOP, Body 6.5 x 4.4 mm, Pitch 0.65 mm; PackageReference: FE-20; PackageVersion: Rev. I, 05/2011; RoHS: TRUE
U3 U6 = 74LVC4066: Quad Bilateral Switch; MYPN: U-74LVC4066; VENDOR: NXP; Component Kind: Standard; Library Name: commonsch-new.SchLib; MPN: 74LVC4066BQ,115
U5 U8 = INA138NA/250: High-side measurement current shunt monitor; MYPN: U-INA138NA/250; VENDOR: TI; Component Kind: Standard; Library Name: commonsch-new.SchLib; MPN: INA138NA/250
U7 U9 = OPA320AIDBVT: Precision, 20 MHz, 0.9 pA Ib, RRIO, CMOS Operational Amplifier, 1.8 to 5.5 V, -40 to 125 degC, 5-pin SOT23 (DBV5), Green (RoHS & no Sb/Br); Manufacturer: Texas Instruments; CMRR(Min)(dB): 100; IIB(Max)(pA): 0.9; Iq per channel(Max)(mA): 1.75; MYPN: U-OPA320AIDBVT; Number of Channels: 2; Offset Drift(Typ)(uV/C): 1.5; Slew Rate(Typ)(V/us): 10; Sub Family: High Output Current Amplifier (>=50mA), Low Input Bias Current Amp (<=10pA), Low Noise Amp (<=10nV/rtHz), Low Offset Voltage Amplifier (<=500uV), Low Supply Voltage Amplifier (<=2.7V), Precision Amplifier; Total Supply Voltage(Max)(+5V is 5, +/-5V is 10): 5.5; Total Supply Voltage(Min)(+5V is 5, +/-5V is 10): 1.8; VENDOR: TI; Vio (25C)(Max)(mV): 0.15; Vn at 1kHz(Typ)(nV/rtHz): 8.5; Code_JEDEC: MO-178; Component Kind: Standard; ComponentLink1Description: Manufacturer URL; ComponentLink1URL: http://www.ti.com/; ComponentLink2Description: Datasheet; ComponentLink2URL: http://www.ti.com/general/docs/lit/getliterature.tsp?genericPartNumber=OPA320&fileType=pdf; ComponentLink3Description: Package Specification; ComponentLink3URL: http://www.ti.com/litv/pdf/mpds018i; DatasheetVersion: SBOS513D; Eco-Plan: Green (RoHS & no Sb/Br); Library Name: TI Amplifiers and Linear Operational Amplifier Precision Amplifier Part One.IntLib; Mounting Technology: Surface Mount; MPN: OPA320AIDBVT; PackageDescription: 5-Pin Plastic Small Outline, Body 1.6 x 2.9 mm, Pitch 0.95 mm; PackageReference: DBV5; PackageVersion: 4073253-4/K, 03/2006; PartNumber: OPA320AIDBVT; Supplier 1: Digi-Key; Supplier 2: Digi-Key; Supplier 3: Digi-Key; Supplier 4: Farnell; Supplier 5: Newark; Supplier 6: Newark; Supplier 7: Mouser; Supplier Part Number 1: 296-29510-2-ND; Supplier Part Number 2: 296-29510-1-ND; Supplier Part Number 3: 296-29510-6-ND; Supplier Part Number 4: 2057093; Supplier Part Number 5: 64T3763; Supplier Part Number 6: 64T3764; Supplier Part Number 7: 595-OPA320AIDBVT
U10 = LP3982IMM-3.3/NOPB: Micropower, Ultra Low-Dropout, Low-Noise, 300mA CMOS Regulator, 8-pin Mini SOIC, Pb-Free; Manufacturer: National Semiconductor; CompType: LDO IC; High-Reliability: Y; MYPN: ULP3982IMM-3.3/NOPB; PackageTopMarking: ZXTT*LEUB; PowerWise: N; VENDOR: TI; Code_JEDEC: MO-187-AA; Component Kind: Standard; ComponentLink1Description: Manufacturer URL; ComponentLink1URL: http://www.national.com/; ComponentLink2Description: LP3982 Datasheet; ComponentLink2URL: http://www.national.com/ds/LP/LP3982.pdf; ComponentLink3Description: LP3982 Web Page; ComponentLink3URL: http://www.national.com/pf/LP/LP3982.html; ComponentLink4Description: MUA08A Package Page; ComponentLink4URL: http://www.national.com/packaging/folders/mua08a.html; DatasheetVersion: Mar-2006; Library Name: National Semiconductor LDO.IntLib; MPN: LP3982IMM-3.3/NOPB; PackageDescription: MINI SOIC, .118 x .118 x .034in, 8 Lead, .0256in Pitch; PackageReference: MUA08A; PackageVersion: revF, Apr-2008; PartNumber: LP3982IMM-3.3/NOPB; PinCount: 8; RoHS: Y
U11 = TPS54327DDA: 3-A Output Single Synchronous Step-Down Buck with Integrated FET; MPN: TPS54327DDA(R); MYPN: U-TPS54327DDA; VENDOR: TI; Component Kind: Standard; Library Name: commonsch-new.SchLib
